# Altium schematic sheet STM32F10RBT6通用开发板_通信接口.SchDoc
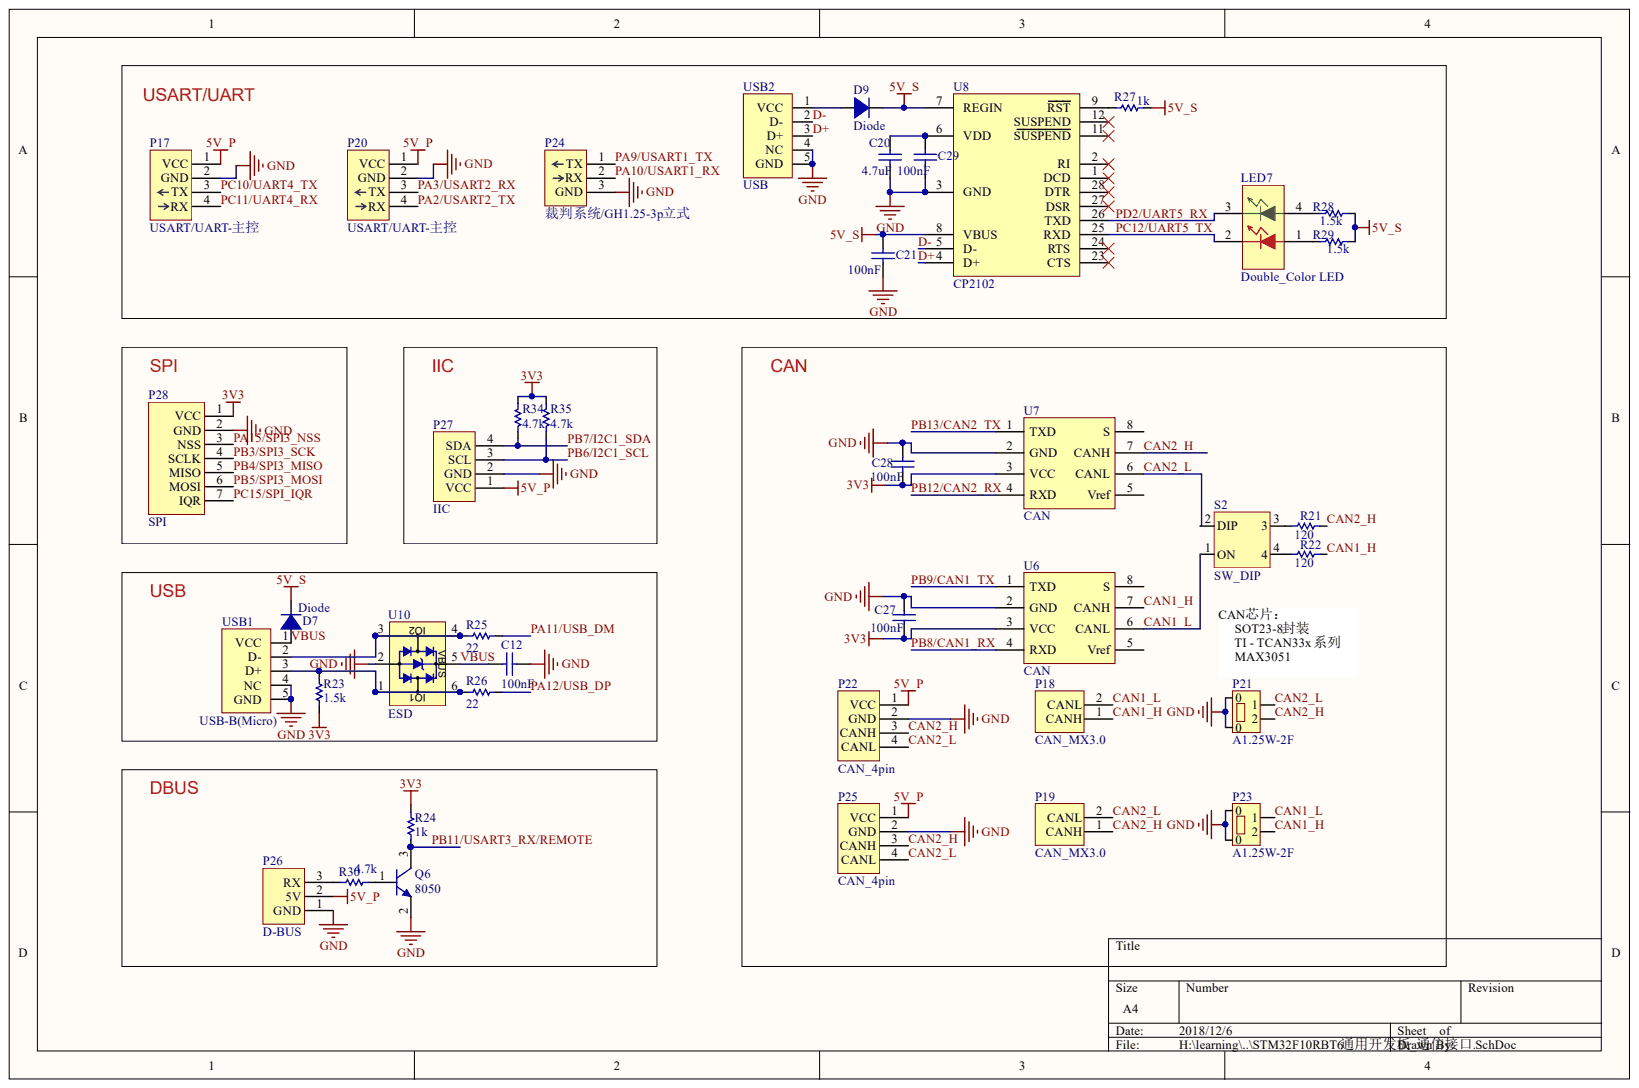
[diagram p0: STM32F10RBT6通用开发板_通信接口.SchDoc]

## Parts
C12  100nF  [0402]
C20  4.7uF  [0603C]
C21  100nF  [0603C]
C27  100nF  [0603C]
C28  100nF  [0603C]
C29  100nF  [0603C]
D7  Diode  [SOD-323-]
D9  Diode  [SOD-323-]
LED7  Double_Color LED  [0805LEDX2]
P17  USART/UART-主控  [HY2.00MM 4X1立式]
P18  CAN_MX3.0  [MX3.0MM 2X1AW]
P19  CAN_MX3.0  [MX3.0MM 2X1AW]
P20  USART/UART-主控  [HY2.00MM 4X1立式]
P21  A1.25W-2F  [GH1.25_立式]
P22  CAN_4pin  [HY2.00MM 4X1立式]
P23  A1.25W-2F  [GH1.25_立式]
P24  裁判系统/GH1.25-3p立式  [A1254-03AWB]
P25  CAN_4pin  [HY2.00MM 4X1立式]
P26  D-BUS  [HY2.00MM 3x1立式]
P27  IIC  [HY2.00MM 4X1立式]
P28  SPI  [A1.25WF-7P]
Q6  8050  [SOT-23-3]
R21  120  [0603R]
R22  120  [0603R]
R23  1.5k  [0402R]
R24  1k  [0603R]
R25  22  [0402R]
R26  22  [0402R]
R27  1k  [0603R]
R28  1.5k  [0603R]
R29  1.5k  [0603R]
R30  4.7k  [0603R]  (value not drawn)
R34  4.7k  [0603R]
R35  4.7k  [0603R]
S2  SW_DIP  [SW_DIP_2x2]
U6  CAN  [SOT-23-8]
U7  CAN  [SOT-23-8]
U8  CP2102  [QFN28N]
U10  ESD  [SOT-666]
USB1  USB-B(Micro)  [USB-micro_A]
USB2  USB  [USB-micro_A]

## Nets
3V3: C27.1 C28.1 P28.1(VCC) R23.2 R24.2 R34.1 R35.1 U6.3(VCC) U7.3(VCC)
5V_P: P17.1(VCC) P20.1(VCC) P22.1(VCC) P25.1(VCC) P26.2 P27.1(VCC)
5V_S: C21.2 D7.2 D9.2 R27.2 R28.2 R29.2 U8.7(REGIN) U8.8(VBUS)
GND: C12.1 C20.1 C21.1 C27.2 C28.2 C29.1 P17.2(GND) P20.2(GND) P21.0 P22.2(GND) P23.0 P24.3 P25.2(GND) P26.1 P27.2(GND) P28.2(GND) Q6.2 U6.2(GND) U7.2(GND) U8.3(GND) U8.29 U10.2 USB1.4(NC) USB1.5(GND) USB2.4(NC) USB2.5(GND)
CAN1_H: P18.1 P23.2 R22.1 U6.7(CANH)
CAN1_L: P18.2 P23.1 S2.1(ON) U6.6(CANL)
CAN2_H: P19.1 P21.2 P22.3(CANH) P25.3(CANH) R21.1 U7.7(CANH)
CAN2_L: P19.2 P21.1 P22.4(CANL) P25.4(CANL) S2.2(DIP) U7.6(CANL)
D+: U8.4(D+) USB2.3(D+)
D-: U8.5(D-) USB2.2(D-)
PA2/USART2_TX: P20.4(RX)
PA3/USART2_RX: P20.3(TX)
PA9/USART1_TX: P24.1
PA10/USART1_RX: P24.2
PA11/USB_DM: R25.2
PA12/USB_DP: R26.2
PA15/SPI3_NSS: P28.3(NSS)
PB3/SPI3_SCK: P28.4(SCLK)
PB4/SPI3_MISO: P28.5(MISO)
PB5/SPI3_MOSI: P28.6(MOSI)
PB6/I2C1_SCL: P27.3(SCL) R35.2
PB7/I2C1_SDA: P27.4(SDA) R34.2
PB8/CAN1_RX: U6.4(RXD)
PB9/CAN1_TX: U6.1(TXD)
PB11/USART3_RX/REMOTE: Q6.3 R24.1
PB12/CAN2_RX: U7.4(RXD)
PB13/CAN2_TX: U7.1(TXD)
PC10/UART4_TX: P17.3(TX)
PC11/UART4_RX: P17.4(RX)
PC12/UART5_TX: LED7.2 U8.25(RXD)
PC15/SPI_IQR: P28.7(IQR)
PD2/UART5_RX: LED7.3 U8.26(TXD)
VBUS: C12.2 D7.1 U10.5 USB1.1(VCC)
NetC20_2: C20.2 C29.2 U8.6(VDD)
NetD9_1: D9.1 USB2.1(VCC)
NetLED7_1: LED7.1 R29.1
NetLED7_4: LED7.4 R28.1
NetP26_3: P26.3 R30.1
NetQ6_1: Q6.1 R30.2
NetR21_2: R21.2 S2.3
NetR22_2: R22.2 S2.4
NetR23_1: R23.1 R26.1 U10.1(IO1) U10.6 USB1.3(D+)
NetR25_1: R25.1 U10.3 U10.4 USB1.2(D-)
NetR27_1: R27.1 U8.9(RST)

Not connected: U6 5(Vref) 8(S); U7 5(Vref) 8(S); U8 1(DCD) 2(RI) 11(SUSPEND) 12(SUSPEND) 23(CTS) 24(RTS) 27(DSR) 28(DTR)

## Library data of the parts
C12 C20 C21 C27-C29 = Cap: Capacitor; Value: 100pF; Component Kind: Standard; LatestRevisionDate: 17-Jul-2002; LatestRevisionNote: Re-released for DXP Platform.; PackageDescription: Radial Cap, Thru-Hole; 2 Leads; 0.3 in Pin Spacing; PackageReference: RAD-0.3; Published: 8-Jun-2000; Signal Integrity: Cap; Simulation: CAP; Publisher: Altium Limited
  C12 C20 C21 C29: Library Name: MyComponents_v2.5.IntLib
  C27 C28: Library Name: MyComponents_v2.4.IntLib
D7 D9 = Diode: Default Diode; Code_JEDEC: DO-214-AB; Component Kind: Standard; LatestRevisionDate: 17-Jul-2002; LatestRevisionNote: Re-released for DXP Platform.; Library Name: MyComponents_v2.5.IntLib; PackageDescription: DO-214-AB/SMC; 2 C-Bend Leads; Body 7.9 x 5.9 mm, inc. leads (LxW); PackageReference: SMC; PackageVersion: Sep-1996; Published: 8-Jun-2000; Signal Integrity: Diode; Simulation: DIODE; Publisher: Altium Limited
P17 P20 = UART_4pin_主控: 带电源串口; Component Kind: Standard; Library Name: MyComponents_v2.4.IntLib
P21 P23 = GH1.25-2P: Component Kind: Standard; Library Name: MyComponents_v2.4.IntLib
P22 P25 = CAN_4pin: CAN 4pin，带5V电源，HY2.0-4A; Component Kind: Standard; Library Name: MyComponents_v2.4.IntLib
P24 = UART_3pin: Component Kind: Standard; Library Name: MyComponents_v2.5.IntLib
Q6 = NPN: NPN Bipolar Transistor; Code_JEDEC: TO-226-AA; Component Kind: Standard; LatestRevisionDate: 17-Jul-2002; LatestRevisionNote: Re-released for DXP Platform.; Library Name: MyComponents_v2.5.IntLib; PackageDescription: TO, Flat Index; 3 In-Line, Axial Leads; Body Dia. 4.8mm; Leads 0.55 x 0.51 mm (max); PackageReference: TO-226-AA; Published: 8-Jun-2000; Signal Integrity: NPN; Simulation: NPN; Publisher: Altium Limited
R21-R23 R25 R26 R28-R30 R34 R35 = Res1: Resistor; Value: 1K; Component Kind: Standard; LatestRevisionDate: 17-Jul-2002; LatestRevisionNote: Re-released for DXP Platform.; PackageDescription: Axial Device, Thru-Hole; 2 Leads; 0.3 in Pin Spacing; PackageReference: AXIAL-0.3; Published: 8-Jun-2000; Signal Integrity: Res; Simulation: RESISTOR; Publisher: Altium Limited
  R21 R22: Library Name: MyComponents_v2.4.IntLib
  R23 R25 R26 R28-R30 R34 R35: Library Name: MyComponents_v2.5.IntLib
R24 R27 = Res1: Resistor; Component Kind: Standard; LatestRevisionDate: 17-Jul-2002; LatestRevisionNote: Re-released for DXP Platform.; Library Name: MyComponents_v2.5.IntLib; PackageDescription: Axial Device, Thru-Hole; 2 Leads; 0.3 in Pin Spacing; PackageReference: AXIAL-0.3; Published: 8-Jun-2000; Signal Integrity: Res; Simulation: RESISTOR; Value: 1K; Publisher: Altium Limited
U6 U7 = TJA1050: Component Kind: Standard; Library Name: MyComponents_v2.4.IntLib
U10 = USBLC6-2: 2 data-line protection ,Protects VBUS; Component Kind: Standard; Library Name: MyComponents_v2.5.IntLib
USB1 USB2 = USB-micro: Component Kind: Standard; Library Name: MyComponents_v2.5.IntLib
LED7 = Double_Color LED: Component Kind: Standard; Library Name: MyComponents_v2.5.IntLib
P18 P19 = CAN_MX3.0: Component Kind: Standard; Library Name: MyComponents_v2.5.IntLib
P26 = D-BUS: Component Kind: Standard; Library Name: MyComponents_v2.4.IntLib
P27 = IIC: Component Kind: Standard; Library Name: MyComponents_v2.4.IntLib
P28 = SPI: Component Kind: Standard; Library Name: MyComponents_v2.4.IntLib
S2 = SW_DIP: Component Kind: Standard; Library Name: MyComponents_v2.4.IntLib
U8 = CP2102: Component Kind: Standard; Library Name: MyComponents_v2.5.IntLib
